# Altium schematic sheet SchemaA-puissance.SchDoc
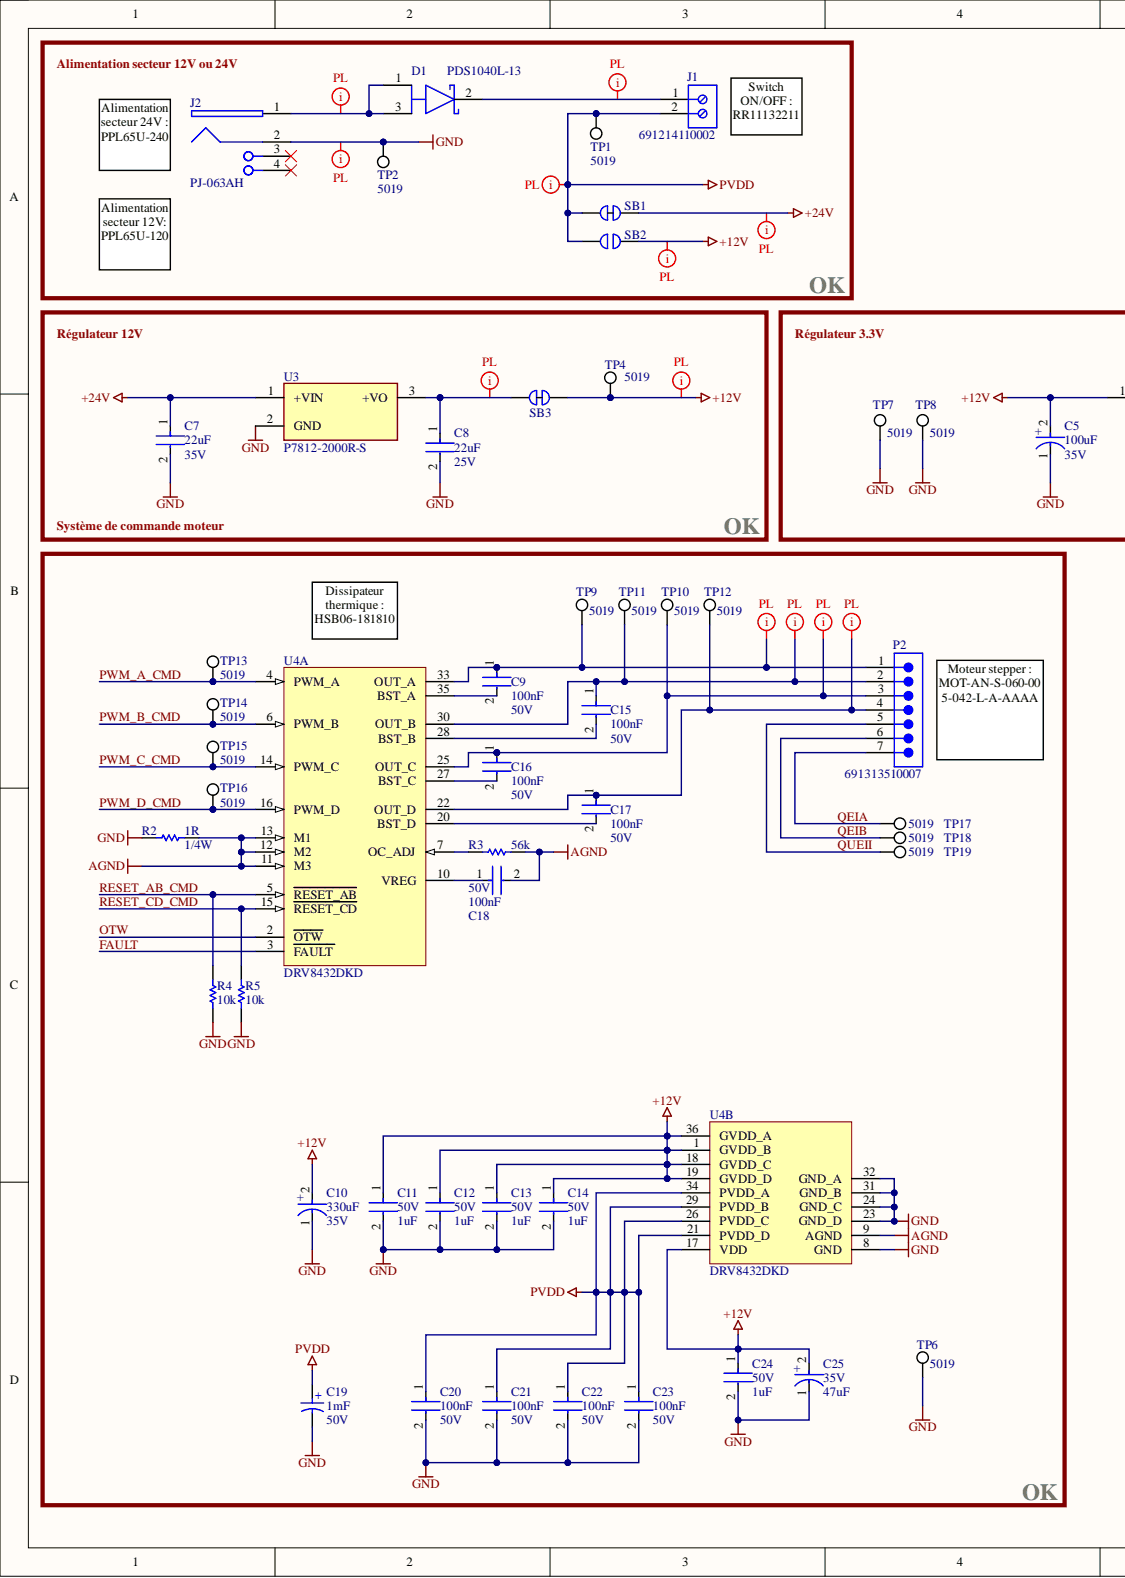
[diagram p0: SchemaA-puissance.SchDoc, left]
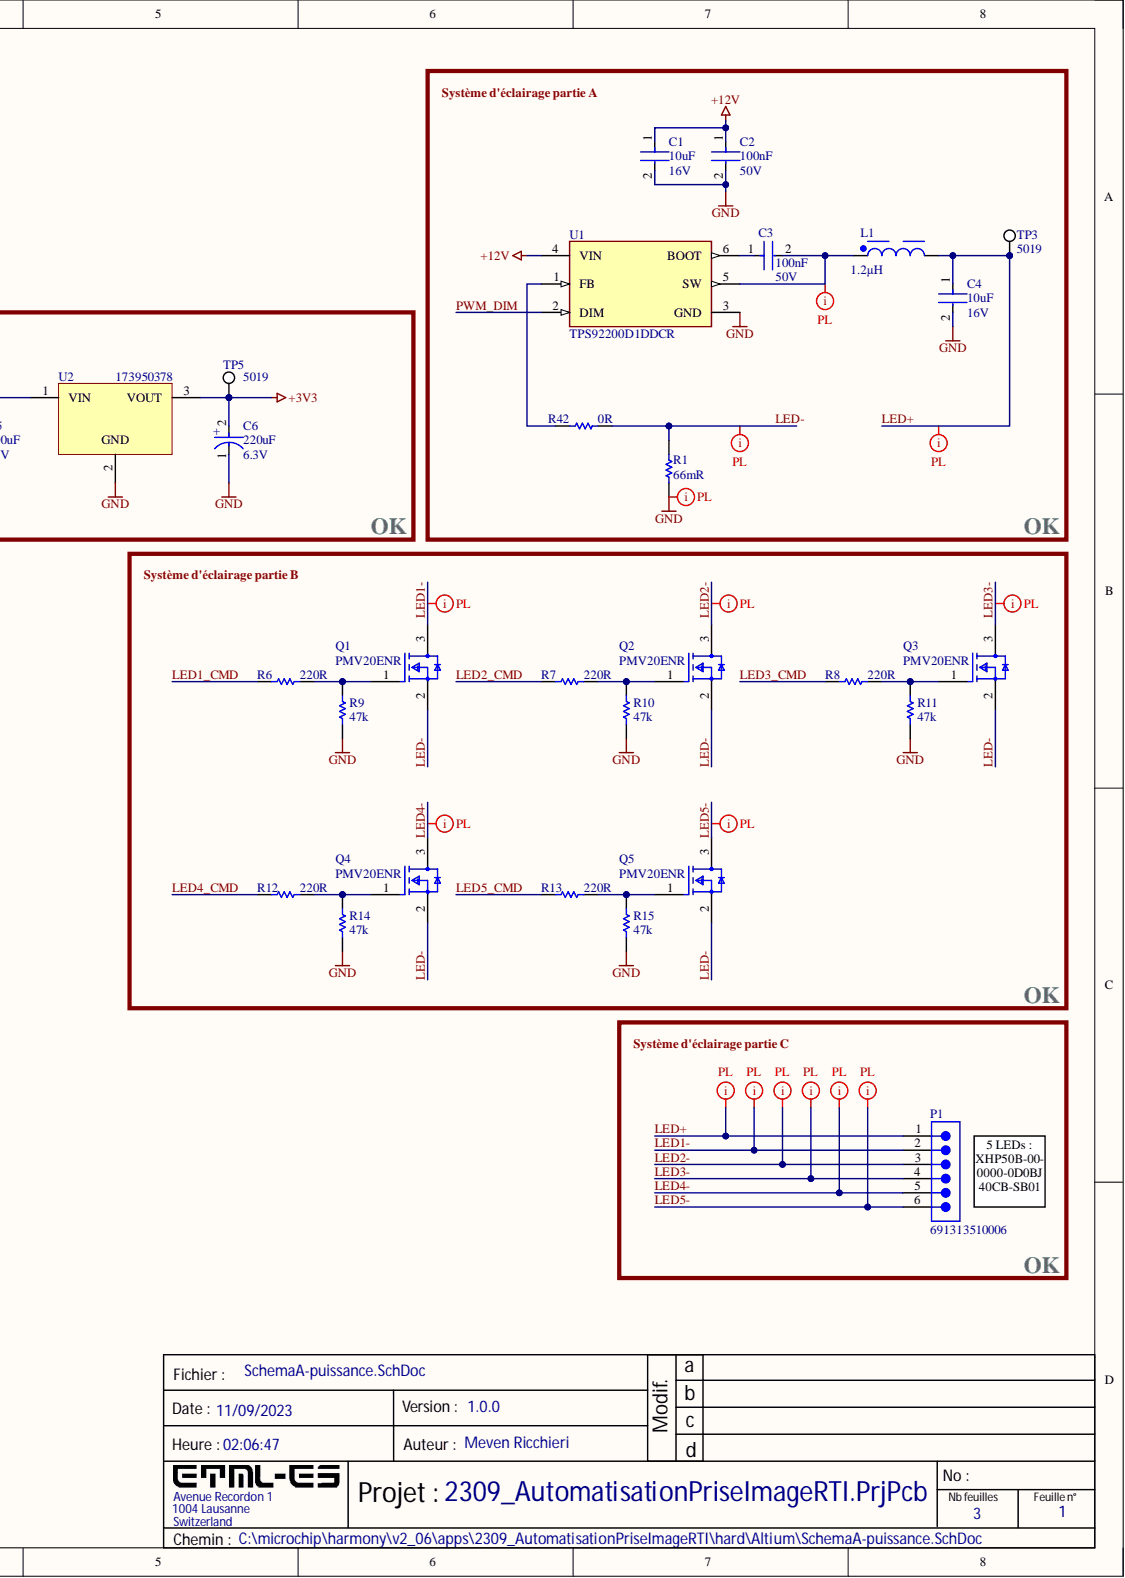
[diagram p1: SchemaA-puissance.SchDoc, right]

## Parts
C1  Capacitance: 10uF  Voltage: 16V  [FP-CL21-IPC_B]  (hidden comment: CL21A106KOQNNNE)
C2  Capacitance: 100nF  Voltage: 50V  [FP-CL21-IPC_C]  (hidden comment: CL21B104KBCNNNC)
C3  Capacitance: 100nF  Voltage: 50V  [FP-CL21-IPC_C]  (hidden comment: CL21B104KBCNNNC)
C4  Capacitance: 10uF  Voltage: 16V  [FP-CL21-IPC_B]  (hidden comment: CL21A106KOQNNNE)
C5  Capacitance: 100uF  Voltage: 35V  [FP-SD8-MFG]  (hidden comment: EEE-1VA101XP)
C6  Capacitance: 220uF  Voltage: 6.3V  [FP-FKD-MFG]  (hidden comment: EEE-FK0J221P)
C7  Capacitance: 22uF  Voltage Rating: 35V  [FP-1210-L_3_2_0_3-W_2_5_0-MFG]  (hidden comment: GMK325BJ226MM-P)
C8  value 22uF  Voltage: 25V  [FP-CL21-IPC_C]  (hidden comment: CL21A226MAYNNNE)
C9  Capacitance: 100nF  Voltage: 50V  [FP-CL21-IPC_C]  (hidden comment: CL21B104KBCNNNC)
C10  Capacitance: 330uF  Voltage: 35V  [FP-SG-MFG]  (hidden comment: EEE-1VA331P)
C11  Capacitance: 1uF  Voltage: 50V  [FP-CL21-IPC_C]  (hidden comment: CL21B105KBFNNNG)
C12  Capacitance: 1uF  Voltage: 50V  [FP-CL21-IPC_C]  (hidden comment: CL21B105KBFNNNG)
C13  Capacitance: 1uF  Voltage: 50V  [FP-CL21-IPC_C]  (hidden comment: CL21B105KBFNNNG)
C14  Capacitance: 1uF  Voltage: 50V  [FP-CL21-IPC_C]  (hidden comment: CL21B105KBFNNNG)
C15  Capacitance: 100nF  Voltage: 50V  [FP-CL21-IPC_C]  (hidden comment: CL21B104KBCNNNC)
C16  Capacitance: 100nF  Voltage: 50V  [FP-CL21-IPC_C]  (hidden comment: CL21B104KBCNNNC)
C17  Capacitance: 100nF  Voltage: 50V  [FP-CL21-IPC_C]  (hidden comment: CL21B104KBCNNNC)
C18  Capacitance: 100nF  Voltage: 50V  [FP-CL21-IPC_C]  (hidden comment: CL21B104KBCNNNC)
C19  Capacitance: 1mF  Voltage Rating: 50V  [WCAP-ASLI_D16H17]  (hidden comment: 865080663020)
C20  Capacitance: 100nF  Voltage: 50V  [FP-CL21-IPC_C]  (hidden comment: CL21B104KBCNNNC)
C21  Capacitance: 100nF  Voltage: 50V  [FP-CL21-IPC_C]  (hidden comment: CL21B104KBCNNNC)
C22  Capacitance: 100nF  Voltage: 50V  [FP-CL21-IPC_C]  (hidden comment: CL21B104KBCNNNC)
C23  Capacitance: 100nF  Voltage: 50V  [FP-CL21-IPC_C]  (hidden comment: CL21B104KBCNNNC)
C24  Capacitance: 1uF  Voltage: 50V  [FP-CL21-IPC_C]  (hidden comment: CL21B105KBFNNNG)
C25  Capacitance: 47uF  Voltage: 35V  [FP-FPD-MFG]  (hidden comment: EEEFP1V470AP)
D1  PDS1040L-13  [FP-PowerDI5-MFG]
J1  691214110002  [691214110002]
J2  PJ-063AH  Voltage Rating: 24V  [CUI-PJ-063AH_V]
L1  Inductance: 1.2µH  [WE-TPC_4828]  (hidden comment: 7440430012)
P1  691313510006  [691313510006]
P2  691313510007  [691313510007]
Q1  PMV20ENR  [FP-SOT23-MFG]
Q2  PMV20ENR  [FP-SOT23-MFG]
Q3  PMV20ENR  [FP-SOT23-MFG]
Q4  PMV20ENR  [FP-SOT23-MFG]
Q5  PMV20ENR  [FP-SOT23-MFG]
R1  value 66mR  [6-0805_M]  (hidden comment: ERJ-L06UF66MV)
R2  value 1R  Power: 1/4W  [RESC2013X60X30NL20T20]  (hidden comment: RK73B2ATTD1R0J)
R3  value 56k  [RESC2013X70X40NL20T20]  (hidden comment: ERJ6ENF5602V)
R4  Resistance: 10k  [RESC2013X60X35ML10T20]  (hidden comment: RC0805JR-0710KL)
R5  Resistance: 10k  [RESC2013X60X35ML10T20]  (hidden comment: RC0805JR-0710KL)
R6  value 220R  [RESC2013X70X40NL20T20]  (hidden comment: ERJ6ENF2200V)
R7  value 220R  [RESC2013X70X40NL20T20]  (hidden comment: ERJ6ENF2200V)
R8  value 220R  [RESC2013X70X40NL20T20]  (hidden comment: ERJ6ENF2200V)
R9  value 47k  [RESC2013X60X35NL10T20]  (hidden comment: RC0805FR-0747KL)
R10  value 47k  [RESC2013X60X35NL10T20]  (hidden comment: RC0805FR-0747KL)
R11  value 47k  [RESC2013X60X35NL10T20]  (hidden comment: RC0805FR-0747KL)
R12  value 220R  [RESC2013X70X40NL20T20]  (hidden comment: ERJ6ENF2200V)
R13  value 220R  [RESC2013X70X40NL20T20]  (hidden comment: ERJ6ENF2200V)
R14  value 47k  [RESC2013X60X35NL10T20]  (hidden comment: RC0805FR-0747KL)
R15  value 47k  [RESC2013X60X35NL10T20]  (hidden comment: RC0805FR-0747KL)
R42  Resistance: 0R  [RESC2013X60X35ML10T20]  (hidden comment: RC0805JR-070RL)
SB1  Solder bridge 3mm close  [Solder bridge]  (value not drawn)
SB2  Solder bridge 3mm open  [Solder bridge]  (value not drawn)
SB3  Solder bridge 3mm close  [Solder bridge]  (value not drawn)
TP1  5019  [KSTN-5019_V]
TP2  5019  [KSTN-5019_V]
TP3  5019  [KSTN-5019_V]
TP4  5019  [KSTN-5019_V]
TP5  5019  [KSTN-5019_V]
TP6  5019  [KSTN-5019_V]
TP7  5019  [KSTN-5019_V]
TP8  5019  [KSTN-5019_V]
TP9  5019  [KSTN-5019_V]
TP10  5019  [KSTN-5019_V]
TP11  5019  [KSTN-5019_V]
TP12  5019  [KSTN-5019_V]
TP13  5019  [KSTN-5019_V]
TP14  5019  [KSTN-5019_V]
TP15  5019  [KSTN-5019_V]
TP16  5019  [KSTN-5019_V]
TP17  5019  [KSTN-5019_V]
TP18  5019  [KSTN-5019_V]
TP19  5019  [KSTN-5019_V]
U1  TPS92200D1DDCR  [FP-DDC0006A-MFG]
U2  173950378  [WPME-FDSM_SIP-3_L11.6W8.5H10.5]
U3  P7812-2000R-S  [P7812-2000R-S]
U4  DRV8432DKD  [SOP65P1420X360-36N - duplicate]  (parts A,B)

## Nets
+3V3: C6.2 TP5.1 U2.3
+12V: C1.1 C2.1 C5.2 C10.2 C11.1 C12.1 C13.1 C14.1 C24.1 C25.2 SB2.2 SB3.1 TP4.1 U1.4(VIN) U2.1 U4.1(GVDD_B) U4.17(VDD) U4.18(GVDD_C) U4.19(GVDD_D) U4.36(GVDD_A)
+24V: C7.1 SB1.2 U3.1
AGND: C18.2 R2.2 R3.2 U4.9(AGND) U4.11(M3) U4.12(M2) U4.13(M1)
GND: C1.2 C2.2 C4.2 C5.1 C6.1 C7.2 C8.2 C10.1 C11.2 C12.2 C13.2 C14.2 C19.2 C20.2 C21.2 C22.2 C23.2 C24.2 C25.1 J2.2 R1.1 R2.1 R4.1 R5.1 R9.1 R10.1 R11.1 R14.1 R15.1 TP2.1 TP6.1 TP7.1 TP8.1 U1.3(GND) U2.2 U3.2 U4.8(GND) U4.23(GND_D) U4.24(GND_C) U4.31(GND_B) U4.32(GND_A)
PVDD: C19.1 C20.1 C21.1 C22.1 C23.1 J1.2 SB1.1 SB2.1 TP1.1 U4.21(PVDD_D) U4.26(PVDD_C) U4.29(PVDD_B) U4.34(PVDD_A)
FAULT: U4.3(FAULT)
LED1-: P1.2 Q1.3
LED1_CMD: R6.1
LED2-: P1.3 Q2.3
LED2_CMD: R7.1
LED3-: P1.4 Q3.3
LED3_CMD: R8.1
LED4-: P1.5 Q4.3
LED4_CMD: R12.1
LED5-: P1.6 Q5.3
LED5_CMD: R13.1
LED+: C4.1 L1.2 P1.1 TP3.1
LED-: Q1.2 Q2.2 Q3.2 Q4.2 Q5.2 R1.2 R42.2
OTW: U4.2(OTW)
PWM_A_CMD: TP13.1 U4.4(PWM_A)
PWM_B_CMD: TP14.1 U4.6(PWM_B)
PWM_C_CMD: TP15.1 U4.14(PWM_C)
PWM_D_CMD: TP16.1 U4.16(PWM_D)
PWM_DIM: U1.2(DIM)
QEIA: P2.7 TP17.1
QEIB: P2.6 TP18.1
QUEII: P2.5 TP19.1
RESET_AB_CMD: R4.2 U4.5(RESET_AB)
RESET_CD_CMD: R5.2 U4.15(RESET_CD)
NetC3_1: C3.1 U1.6(BOOT)
NetC3_2: C3.2 L1.1 U1.5(SW)
NetC8_1: C8.1 SB3.2 U3.3
NetC9_1: C9.1 P2.1 TP9.1 U4.33(OUT_A)
NetC9_2: C9.2 U4.35(BST_A)
NetC15_1: C15.1 P2.2 TP11.1 U4.30(OUT_B)
NetC15_2: C15.2 U4.28(BST_B)
NetC16_1: C16.1 P2.3 TP10.1 U4.25(OUT_C)
NetC16_2: C16.2 U4.27(BST_C)
NetC17_1: C17.1 P2.4 TP12.1 U4.22(OUT_D)
NetC17_2: C17.2 U4.20(BST_D)
NetC18_1: C18.1 U4.10(VREG)
NetD1_1: D1.1 D1.3 J2.1
NetD1_2: D1.2 J1.1
NetQ1_1: Q1.1 R6.2 R9.2
NetQ2_1: Q2.1 R7.2 R10.2
NetQ3_1: Q3.1 R8.2 R11.2
NetQ4_1: Q4.1 R12.2 R14.2
NetQ5_1: Q5.1 R13.2 R15.2
NetR3_1: R3.1 U4.7(OC_ADJ)
NetR42_1: R42.1 U1.1(FB)

Not connected: J2 3 4

## Library data of the parts
C1 C4 = CMP-2000-06102-2: CL21 Series 0805 10uF 16V ±10% Tolerance X5R Multilayer Ceramic Chip Capacitor; Dielectric: X7R; Case Code (Imperial): 0805; Case Code (Metric): 2012; Case/Package: 0805; Component Kind: Standard; Depth: 1.25mm; Height: 1.25mm; Lead Free: Lead Free; Length: 2mm; Library Name: Altium Content Vault; Max Operating Temperature: 85°C; Min Operating Temperature: -55°C; Mount: Surface Mount; Package Quantity: 1; Packaging: Tape and Reel; Radiation Hardening: No; Thickness: 0.055inch; Tolerance: 10%; Voltage Rating: 16V; Voltage Rating (DC): 16V; Width: 0.049inch
C2 C3 C9 C15-C18 C20-C23 = CMP-2000-05017-2: CL21 Series 0805 100nF 50V ±10% Tolerance X7R Multilayer Ceramic Chip Capacitor; Case Code (Imperial): 0805; Case Code (Metric): 2012; Case/Package: 0805; Component Kind: Standard; Contact Plating: Tin; Depth: 1.25mm; Dielectric: X7R; Height: 0.85mm; Lead Free: Lead Free; Length: 2mm; Library Name: Altium Content Vault; Material: Ceramic; Max Operating Temperature: 125°C; Min Operating Temperature: -55°C; Mount: Surface Mount; Packaging: Tape and Reel; Radiation Hardening: No; Thickness: 0.037inch; Tolerance: 10%; Voltage Rating: 50V; Voltage Rating (DC): 50V; Width: 0.049inch
C5 = CMP-05427-000062-1: CAP ALUM 100UF 20% 35V SMD; Leakage Current: 3uA; Life (Hours): 2000Hour; Polarity: Polar; Ripple Current: 132mA; Case/Package: Radial; Component Kind: Standard; Construction: Cylindrical; Contact Plating: Tin; Diameter: 6.3mm; Height: 7.7mm; Height - Seated (Max): 0.303inch; Lead Free: Lead Free; Length: 8mm; Library Name: Altium Content Vault; Max Operating Temperature: 85°C; Min Operating Temperature: -40°C; Mount: Surface Mount; Number of Pins: 8; Package Quantity: 900; Packaging: Tape and Reel; Radiation Hardening: No; REACH SVHC: No SVHC; Ripple Current (AC): 132mA; RoHS Compliant: Yes; Series: S; Termination: SMD/SMT; Tolerance: 20%; Voltage Rating: 35V; Voltage Rating (DC): 35V
C6 = CMP-05427-000053-1: CAP ALUM 220UF 20% 6.3V SMD; ESR (Equivalent Series Resistance): 360mR; Leakage Current: 3uA; Life (Hours): 2000Hour; Material: Aluminium; Polarity: Polar; Ripple Current: 240mA; Case/Package: Radial; Component Kind: Standard; Construction: Cylindrical; Contact Plating: Tin; Diameter: 6.3mm; Height: 5.8mm; Height - Seated (Max): 0.24inch; Impedance: 360mR; Lead Free: Lead Free; Length: 5.8mm; Library Name: Altium Content Vault; Max Operating Temperature: 105°C; Min Operating Temperature: -55°C; Mount: Surface Mount; Number of Pins: 2; Package Quantity: 1000; Packaging: Tape and Reel; Radiation Hardening: No; REACH SVHC: No SVHC; Ripple Current (AC): 240mA; RoHS Compliant: Yes; Series: FK; Size: 5.8mm; Termination: SMD/SMT; Tolerance: 20%; Voltage Rating: 6.3V; Voltage Rating (DC): 6.3V; Width: 7.8mm
C7 = CMP-14477-001772-2: None; Tolerance: 20%; Case Code (Imperial): 1210; Case Code (Metric): 3225; Case/Package: 1210; Component Kind: Standard; Lead Free: Lead Free; Library Name: Altium Content Vault; Max Operating Temperature: 85°C; Min Operating Temperature: -55°C; Mount: Surface Mount; Packaging: Cut Tape; RoHS Compliant: Yes; Simulation: GMK325BJ226_M-P
C8 = CMP-13271-003225-1: CL21 Series 0805 22uF 25V ±20% Tolerance X5R Multilayer Ceramic Chip Capacitor; Component Kind: Standard; Library Name: Altium Content Vault
C10 = CMP-05427-002166-1: CAP ALUM 330UF 20% 35V SMD; Leakage Current: 3uA; Life (Hours): 2000Hour; Polarity: Polar; Ripple Current: 350mA; Case/Package: Radial; Component Kind: Standard; Construction: Cylindrical; Contact Plating: Tin; Diameter: 10mm; Height: 10.2mm; Height - Seated (Max): 0.402inch; Lead Free: Lead Free; Length: 10.5mm; Library Name: Altium Content Vault; Max Operating Temperature: 85°C; Min Operating Temperature: -40°C; Mount: Surface Mount; Package Quantity: 500; Packaging: Tape and Reel; Radiation Hardening: No; Ratings: AEC-Q200; Reach SVHC Compliant: Yes; Ripple Current (AC): 350mA; RoHS Compliant: Yes; Series: S; Termination: SMD/SMT; Tolerance: 20%; Voltage Rating: 35V; Voltage Rating (DC): 35V
C11-C14 C24 = CMP-13271-001433-1: CL21 Series 0805 1uF 50V ±10% Tolerance X7R Multilayer Ceramic Chip Capacitor; Case Code (Imperial): 0805; Case Code (Metric): 2012; Case/Package: 0805; Component Kind: Standard; Contact Plating: Tin; Depth: 1.25mm; Dielectric: X7R; Length: 2mm; Library Name: Altium Content Vault; Material: Ceramic; Max Operating Temperature: 125°C; Min Operating Temperature: -55°C; Mount: Surface Mount; Package Quantity: 3000; Packaging: Tape and Reel; Termination: Wraparound; Thickness: 0.053inch; Tolerance: 10%; Voltage Rating: 50V; Width: 0.049inch
C19 = CMP-03913-53914628-1: 865080663020 WCAP-ASLI Aluminum Electrolytic Capacitors; ESR (Equivalent Series Resistance): 60.8615mΩ; Impedance: 78mΩ; Insulation Resistance: 100kΩ; Leakage Current: 500µA; Life (Hours): 2000hours; Polarity: Polar; Ripple Current: 1A; Tolerance: 20%; Case/Package: Radial; Component Kind: Standard; Diameter: 16mm; Height: 17mm; Height - Seated (Max): 16.9926mm; Length: 17mm; Library Name: Altium Content Vault; Max Operating Temperature: 105°C; Min Operating Temperature: -55°C; Mount: Surface Mount; NexarPartId: 53914628; Packaging: Tape & Reel (TR); REACH SVHC: Unknown; Ripple Current (AC): 1A; RoHS: Compliant; Voltage Rating (DC): 50V; Width: 17.1mm
C25 = CMP-2000-06216-2: CAP ALUM 47UF 20% 35V SMD; Dissipation Factor: 0.12%; ESR (Equivalent Series Resistance): 260mR; Leakage Current: 3uA; Life (Hours): 2000Hour; Material: Aluminium; Ripple Current: 300mA; Case/Package: Radial; Component Kind: Standard; Construction: Cylindrical; Contact Plating: Tin; Diameter: 6.3mm; Height: 5.8mm; Height - Seated (Max): 0.228inch; Lead Free: Lead Free; Lead Pitch: 1.8mm; Length: 5.8mm; Library Name: Altium Content Vault; Max Operating Temperature: 105°C; Min Operating Temperature: -55°C; Mount: Surface Mount; Number of Pins: 2; Package Quantity: 1; Packaging: Tape and Reel; Radiation Hardening: No; REACH SVHC: No SVHC; Ripple Current (AC): 300mA; RoHS Compliant: Yes; Series: FP; Termination: SMD/SMT; Tolerance: 20%; Voltage Rating: 35V; Voltage Rating (DC): 35V
D1 = CMP-12790-000057-1: DIODE SCHOTTKY 40V 10A POWERDI5; Manufacturer: Diodes Inc.; Automotive Grade: Grade 0; Max Junction Temp: +150°C; Max Surge Forward Current: 275A; Peak Reverse Current: 0.07mA; Standoff Height: 0mm; Automotive: Yes; Category: Diode; Component Kind: Standard; ComponentLink2Description: Datasheet; ComponentLink2URL: https://www.diodes.com/assets/Datasheets/ds30486.pdf; Device Class L1: Discrete Semiconductors; Device Class L2: Diodes; Device Class L3: Schottky Diodes; Digikey Description: DIODE SCHOTTKY 40V 10A POWERDI5; Footprint Url: https://www.diodes.com/assets/Package-Files/PowerDI5.pdf; Forward Current: 10A; Height: 1.15mm; Lead Free: Yes; Library Name: Altium Content Vault; Manufacturer Part Number: PDS1040L-13; Max Repetitive Reverse Voltage: 40V; Mouser Description: PDS1040L Series 40 V 10 A Low VF Schottky Barrier Rectifier - PowerDI? 5; Octopart: https://octopart.com/pds1040l-13-diodes+inc.-332540; Package: PowerDI5; Reverse Voltage: 40V; RoHS: TRUE; Temperature: -65°C to +150°C; Temperature Range High: +150°C; Temperature Range Low: -65°C
J1 = CMP-1502-02464-2: NexarPartId: 18818288; Current: 10A; Number of Contacts: 2; Number of Levels: 1; Pitch: 3.5052mm; Plating: Brass, Steel; Stripping Length: -6mm; Voltage: 300V; Wire Gauge (Max): 24AWG; Wire Gauge (Min): 16AWG; Color: Blue, Green; Component Kind: Standard; Contact Material: Brass; Contact Plating: Brass, Tin; Current Rating: 10A; Height: 8.7mm; Housing Material: Nylon; Lead Pitch: 3.5mm; Length: 7.4mm; Library Name: Altium Content Vault; Max Operating Temperature: 105°C; Min Operating Temperature: -40°C; Mount: Through Hole; Number of Pins: 2; Number of Positions Per Level: 2; Number of Rows: 1; Packaging: Box; REACH SVHC: Unknown; RoHS: Compliant; Termination: Screw; Voltage Rating: 300V; Width: 7.9mm; Wire/Cable Gauge: 24AWG
J2 = CMP-2000-06898-1: Through Hole Right Angle DC Power Jack, 8 A, 2 mm Center Pin, 2 Position, -25 to 85 degC, RoHS, Bulk; Manufacturer: CUIInc.; Brand: CUI; Current Rating: 8A; Inside Contact Diameter: 2mm; Insulation Resistance: 100MOhms; Outside Contact Diameter: 6.5mm; Component Kind: Standard; Connector Type: DCPowerJack; Contact Gender: Male; Contact Material: Copper; Contact Plating: Nickel; Datasheet URL: http://www.cui.com/product/resource/pj-063ah.pdf; Datasheet Version: Rev. 1.02, 03/2016; Factory Pack Quantity: 500; Flammability Rating: UL94V-0; Housing Material: PolybutyleneTerephthalate(PBT); Library Name: Altium Content Vault; Manufacturer URL: http://www.cui.com/; Mounting Angle: Right; Mounting Style: KinkedPin; Mounting Technology: ThroughHole; Operating Temperature Range: -25Cto+85C; Package Description: 2-Pin Through Hole Device, Body 13 x 10 mm, Height 9 mm; Package Reference: PJ-063AH; Product: Jacks; Product Category: DCPowerConnectors; RoHS: True; Termination Style: ThroughHole
L1 = CMP-0227-00340-3: NexarPartId: 8917077; Core Material: Ferrite; Current Rating: 3.1A; DC Resistance (DCR): 17mΩ; Inductance Tolerance: 30%; Saturation Current: 2.8A; Self Resonant Frequency: 150MHz; Shielding: Shielded; Test Frequency: 100kHz; Ambient Temperature Range High: 95°C; Ambient Temperature Range Low: -40°C; Case Code (Imperial): 1919; Case Code (Metric): 4848; Case/Package: 1919; Component Kind: Standard; Composition: Wirewound; Depth: 4.8mm; Height: 2.8mm; Height - Seated (Max): 2.9972mm; Lead Free: Lead Free; Length: 4.8mm; Library Name: Altium Content Vault; Max DC Current: 3.1A; Max Operating Temperature: 125°C; Min Operating Temperature: -40°C; Mount: Surface Mount; Number of Pins: 2; Packaging: Cut Tape (CT); REACH SVHC: Unknown; Resistance: 17mΩ; RMS Current (Irms): 3.1A; RoHS: Compliant; Termination: SMD/SMT; Tolerance: 30%; Weight: 256.988427mg; Width: 4.8mm
P1 = CMP-1502-02196-2: NexarPartId: 8917763; Current: 20A; Gender: Male; Number of Contacts: 6; Number of Levels: 1; Pitch: 5.08mm; Voltage: 300V; Color: Green; Component Kind: Standard; Contact Gender: Male; Contact Material: Brass; Contact Plating: Tin; Height: 12mm; Lead Pitch: 5.08mm; Length: 11.5mm; Library Name: Altium Content Vault; Mount: Through Hole; Number of Pins: 6; Number of Positions Per Level: 6; Number of Rows: 1; Packaging: Box; REACH SVHC: No SVHC; RoHS: Compliant; Termination: Solder; Width: 32.48mm
P2 = CMP-1502-02197-2: NexarPartId: 105951014; Component Kind: Standard; Library Name: Altium Content Vault
Q1-Q5 = CMP-14409-000013-1: MOSFET N-CH 30V SOT23; Component Kind: Standard; Library Name: Altium Content Vault
R1 = Res1: Resistor; Component Kind: Standard; LatestRevisionDate: 17-Jul-2002; LatestRevisionNote: Re-released for DXP Platform.; Library Name: Miscellaneous Devices.IntLib; PackageDescription: Axial Device, Thru-Hole; 2 Leads; 0.3 in Pin Spacing; PackageReference: AXIAL-0.3; Published: 8-Jun-2000; Simulation: RESISTOR; Publisher: Altium Limited
R2 = CMP-2001-01793-1: Manufacturer: KOA Speer; Component Kind: Standard; Library Name: Altium Content Vault; Part Number: RK73B2ATTD1R0J
R3 = CMP-2001-00559-1: Manufacturer: Panasonic; Component Kind: Standard; Library Name: Altium Content Vault; Part Number: ERJ6ENF5602V
R4 R5 = CMP-2000-05025-1: Chip Resistor, 10 KOhm, +/- 5%, 0.125 W, -55 to 155 degC, 0805 (2012 Metric), RoHS, Tape and Reel; Manufacturer: Yageo; Power Rating: 250mW; Product Range: RCSeries; Resistor Case Style: 0805[2012Metric]; Resistor Element Type: ThickFilm; SVHC: ToBeAdvised; Temperature Coefficient: ±100ppm/degC; Voltage Rating: 150V; Automotive Qualification Standard: -; Component Kind: Standard; Datasheet URL: http://www.yageo.ru/pdf/RC0805.pdf; Datasheet Version: REV. 2, 09/2004; Library Name: Altium Content Vault; Manufacturer URL: http://www.yageo.com/; MSL: MSL1-Unlimited; Package Description: 2-Pin Surface Mounted Device, Body 2 x 1.25 mm; Package Reference: 805; Packaging: CutTape; Resistance Tolerance: ±5%
R6-R8 R12 R13 = CMP-2001-00044-1: Manufacturer: Panasonic; Component Kind: Standard; Library Name: Altium Content Vault; Part Number: ERJ6ENF2200V
R9-R11 R14 R15 = CMP-1659-00011-4: Chip Resistor, 47 KOhm, +/- 1%, 0.125 W, -55 to 155 degC, 0805 (2012 Metric), RoHS, Tape and Reel; Manufacturer: Yageo; Voltage Rating: 150 V; Component Kind: Standard; ComponentLink1Description: Manufacturer URL; ComponentLink1URL: http://www.yageo.com/; ComponentLink2Description: Datasheet; ComponentLink2URL: http://www.yageo.com/documents/recent/PYu-RC_Group_51_RoHS_L_04.pdf; DatasheetVersion: Rev. 4, 02/2016; Height: 0.5 mm; Length: 2 mm; Library Name: Altium Content Vault; Max Operating Temperature: 155 degC; Min Operating Temperature: -55 degC; Mount: Surface Mount; Mounting Technology: Surface Mount; Package Quantity: 200; PackageDescription: 2-Pin Surface Mounted Device, Body 2 x 1.25 mm; PackageReference: 0805; Packaging: Tape and Reel; Pins: 2; REACH SVHC: No SVHC; RoHSCompliant: true
R42 = CMP-2100-03673-1: Chip Resistor, 0 Ohm, Jumper, +/- 5%, 0.125 W, -55 to 155 degC, 0805 (2012 Metric), RoHS, Tape and Reel; Manufacturer: Yageo; Power Rating: 125mW; Temperature Coefficient: 200ppm/degreesC; Voltage Rating: 150V; Case Code (Imperial): 0805; Case Code (Metric): 2012; Case/Package: 0805; Component Kind: Standard; Composition: ThickFilm; Contact Plating: Tin; Datasheet URL: http://www.yageo.com/NewPortal/yageodocoutput?fileName=/pdf/R-Chip/PYu-RC_Group_51_RoHS_L_5.pdf; Datasheet Version: Rev. 5, 10/2016; Depth: 1.25mm; Height: 0.5mm; Lead Free: LeadFree; Length: 2mm; Library Name: Altium Content Vault; Manufacturer URL: http://www.yageo.com/; Max Operating Temperature: 155degC; Military Standard: Not; Min Operating Temperature: -55degC; Number of Pins: 2; Package Description: 2-Pin Surface Mounted Device, Body 2 x 1.25 mm; Package Reference: 0805; Packaging: TapeandReel; Radiation Hardening: No; REACH SVHC: Unknown; RoHSCompliant: true; Termination: SMD/SMT; Tolerance: 5%; Voltage Rating (DC): 150V; Width: 1.25mm
TP1-TP19 = CMP-1672-00008-1: Test Point, 1 Position SMD, RoHS, Tape and Reel; Component Kind: Standard; ComponentLink1Description: Manufacturer URL; ComponentLink1URL: http://www.keyelco.com/; ComponentLink2Description: Datasheet; ComponentLink2URL: http://www.keyelco.com/product-pdf.cfm?p=1357; Contact Plating: Silver; DatasheetVersion: 03/2014; Library Name: Altium Content Vault; Mount: Surface Mount; Mounting Technology: Surface Mount; Package Quantity: 1000; PackageDescription: 1-Pin Surface Mount Device, Body 3.81 x 2.03 mm; PackageReference: 5019; Packaging: Tape and Reel; Pins: 1; RoHSCompliant: True
U1 = CMP-04914-000046-1: Component Kind: Standard; Description: TPS92200D1DDCR; Library Name: Altium Content Vault
U2 = CMP-03913-65436605-1: 173950378 Module DC-DC 1-OUT 3.3V 0.5A 3-Pin SIP Module Tube; Efficiency: 95%; Max Input Voltage: 28V; Max Output Current: 500mA; Max Power Dissipation: 1.65W; Max Switching Frequency: 570kHz; Min Input Voltage: 6V; Number of Outputs: 1; Output Type: Fixed; Case/Package: Module; Component Kind: Standard; Features: OTP, OVP, SCP; Height: 10.5mm; Length: 11.6mm; Library Name: Altium Content Vault; Max Operating Temperature: 85°C; Max Output Voltage: 3.3V; Min Operating Temperature: -40°C; Mount: Through Hole; NexarPartId: 65436605; Nominal Output Voltage: 3.3V; Number of Pins: 3; Output Current: 500mA; Output Power: 1.65W; Output Voltage: 3.3V; REACH SVHC: Unknown; RoHS: Compliant; Switching Frequency: 570kHz; Voltage - Output 1: 3.3V; Width: 8.5mm
U4 = DRV8432DKD: 12 A Dual Brushed DC or Single Bipolar Stepper Motor Driver (PWM Ctrl), 0 to 52.5 V, -40 to 85 degC, 36-pin SOP (DKD36), Green (RoHS & no Sb/Br); Peak Output Current(A): 12; rDS (ON) (LS + HS)(mOhms): 220; RMS Output Current(A): 7; Vs(Max)(V): 52.5; Vs(Min)(V): 0; Component Kind: Standard; ComponentLink1Description: Manufacturer URL; ComponentLink1URL: http://www.ti.com/; ComponentLink2Description: Datasheet; ComponentLink2URL: http://www.ti.com/general/docs/lit/getliterature.tsp?genericPartNumber=DRV8432&fileType=pdf; ComponentLink3Description: Package Specification; ComponentLink3URL: http://www.ti.com/lit/ml/mpds126j/mpds126j.pdf; Control I/F: PWM; DatasheetVersion: SLES242D; Eco-Plan: Green (RoHS & no Sb/Br); Mounting Technology: Surface Mount; Package Reference: DKD0036A; PackageDescription: 36-Pin Plastic Small Outline, Body 15.9 x 11 mm, Pitch 0.65 mm; PackageVersion: 4204421-2/K, 11/2013; PartNumber: DRV8432DKD; Library Name: SymbLib.SchLib
SB1 SB3 = Solder bridge 3mm close: Component Kind: Standard; Library Name: SymbLib.SchLib
SB2 = Solder bridge 3mm open: Component Kind: Standard; Library Name: SymbLib.SchLib
U3 = P7812-2000R-S: Component Kind: Standard; Library Name: SymbLib.SchLib
